# Altium schematic sheet VCC38.SchDoc
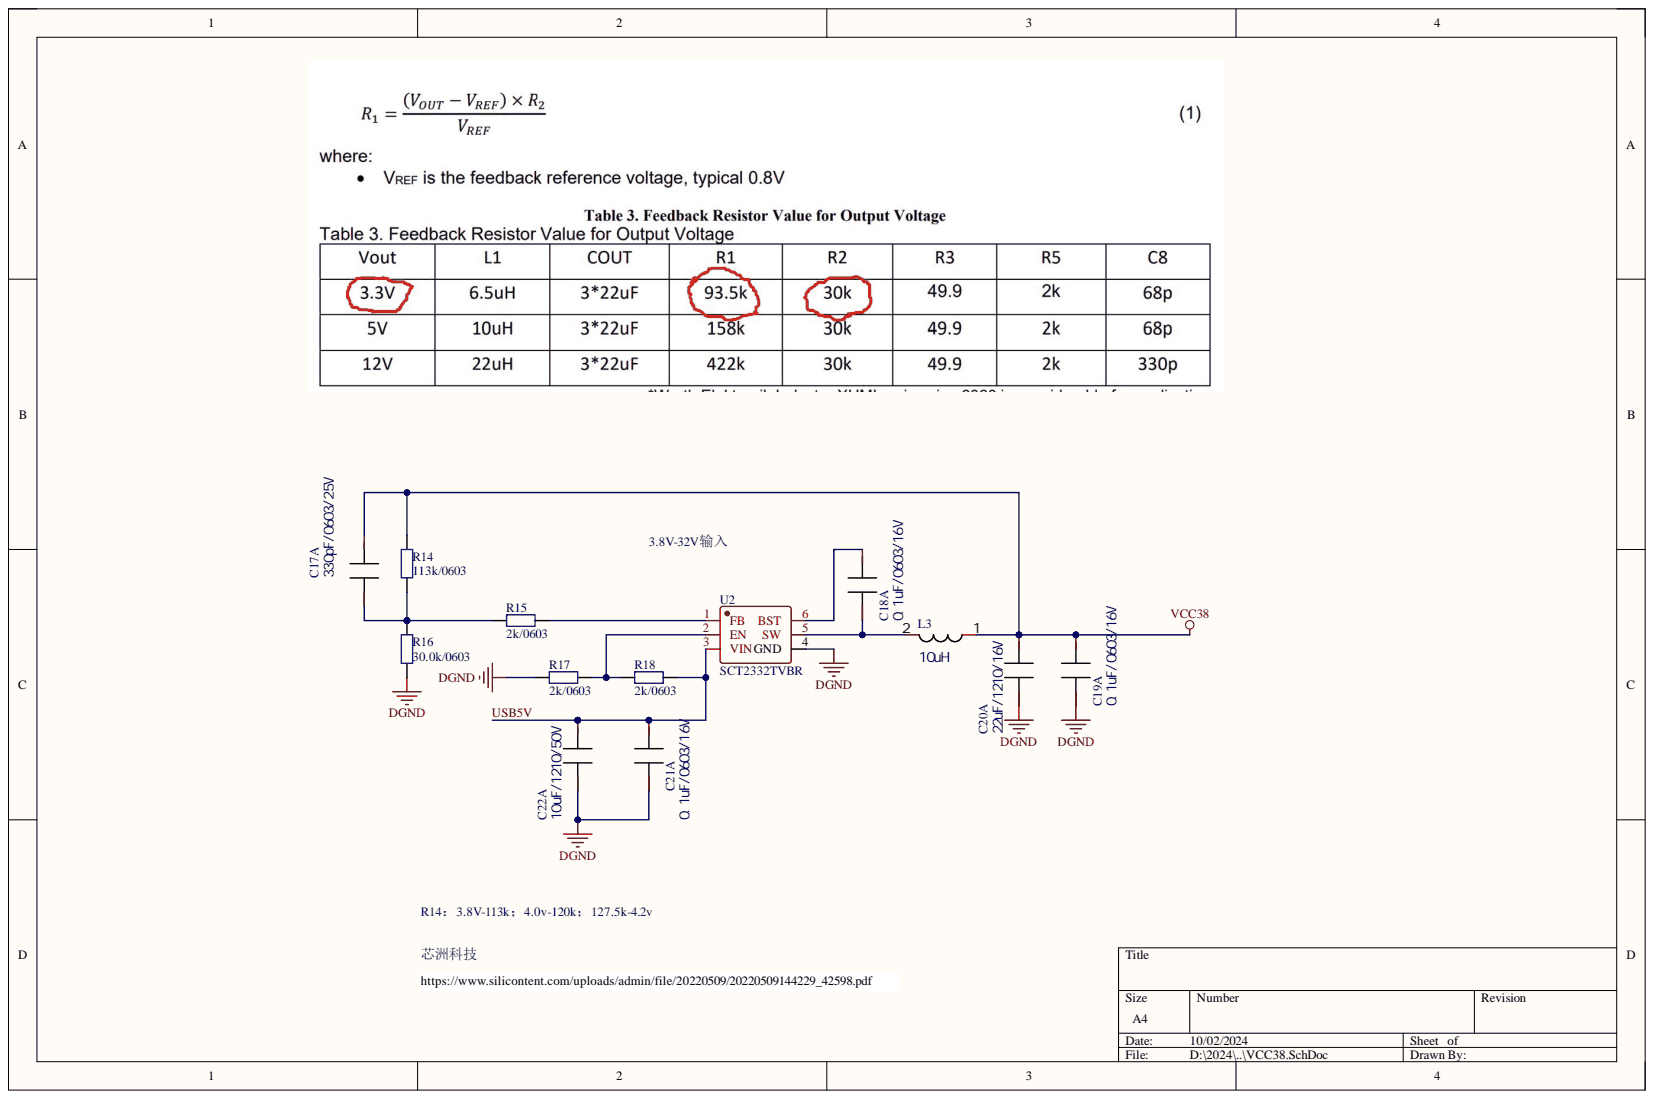
[diagram p0: VCC38.SchDoc]

## Parts
C17  330pF/0603/25V  [CAP0603]  (part A)
C18  0.1uF/0603/16V  [CAP0603]  (part A)
C19  0.1uF/0603/16V  [CAP0603]  (part A)
C20  22uF/1210/16V  [CAP1210-CAPC3225X140N]  (part A)
C21  0.1uF/0603/16V  [CAP0603]  (part A)
C22  10uF/1210/50V  [CAP1210-CAPC3225X140N]  (part A)
L3  10uH  [IND-SMD_L4.7-W4.7-6.5uH]
R14  113k/0603  [RES0603]
R15  2k/0603  [RES0603]
R16  30.0k/0603  [RES0603]
R17  2k/0603  [RES0603]
R18  2k/0603  [RES0603]
U2  SCT2332TVBR  [SCT2332TVBR-TSOT23-6]

## Nets
DGND: C19.2 C20.2 C21.2 C22.2 R16.2 R17.2 U2.4(GND)
VCC38: C17.1 C19.1 C20.1 L3.1 R14.1
USB5V: C21.1 C22.1 R18.1 U2.3(VIN)
NetC17_2: C17.2 R14.2 R15.2 R16.1
NetC18_1: C18.1 U2.6(BST)
NetC18_2: C18.2 L3.2 U2.5(SW)
NetR15_1: R15.1 U2.1(FB)
NetR17_1: R17.1 R18.2 U2.2(EN)

## Library data of the parts
C17 = CAP0603: SURFACE MOUNT CAPACITOR 0.031 X 0.061 INCHES; Manufacturer #1: IPC SM-782 STD.; Add into BOM: yes; Origin Footprint: 0603; Sim_Analog_Order: Model$; Component Kind: Standard; Convert to PCB: yes; Device: CAP0603; Name: CAP0603; OldName: CAP0603; PCB DECAL: 0603; Symbol: CAP0603; Value: 330pF/0603/25V
C18 C19 C21 = CAP0603: SURFACE MOUNT CAPACITOR 0.031 X 0.061 INCHES; Manufacturer #1: IPC SM-782 STD.; Add into BOM: yes; Origin Footprint: 0603; Sim_Analog_Order: Model$; Value: 0.1uF/0603/50V; Component Kind: Standard; Convert to PCB: yes; Device: CAP0603; Name: CAP0603; OldName: CAP0603; PCB DECAL: 0603; Symbol: CAP0603
C20 = CAP0603: SURFACE MOUNT CAPACITOR 0.031 X 0.061 INCHES; Manufacturer #1: IPC SM-782 STD.; Add into BOM: yes; Origin Footprint: 0603; Sim_Analog_Order: Model$; Value: 22uF/1210/35V; Component Kind: Standard; Convert to PCB: yes; Device: CAP0603; Name: CAP0603; OldName: CAP0603; PCB DECAL: 0603; Symbol: CAP0603
C22 = CAP0603: SURFACE MOUNT CAPACITOR 0.031 X 0.061 INCHES; Manufacturer #1: IPC SM-782 STD.; Add into BOM: yes; Origin Footprint: 0603; Sim_Analog_Order: Model$; Value: 10uF/1210/35V; Component Kind: Standard; Convert to PCB: yes; Device: CAP0603; Name: CAP0603; OldName: CAP0603; PCB DECAL: 0603; Symbol: CAP0603
L3 = IND-MOLDED: Add into BOM: yes; Description" MOLDED INDUCTOR, 0_5: PIN SPACING; Origin Footprint: L_0650; Sim_Analog_Order: Model$; Sim_Analog_Prefix: L; Component Kind: Standard; Convert to PCB: yes; Device: IND-MOLDED; Name: IND-MOLDED; OldName: IND-MOLDED; PCB DECAL: L_0650; Symbol: IND-MOLDED; Value: 10uH
R14-R18 = Res2: Resistor; Component Kind: Standard; LatestRevisionDate: 17-Jul-2002; LatestRevisionNote: Re-released for DXP Platform.; Library Name: Miscellaneous Devices.IntLib; PackageDescription: Axial Device, Thru-Hole; 2 Leads; 0.4 in Pin Spacing; PackageReference: AXIAL-0.4; Published: 8-Jun-2000; Signal Integrity: Res; Simulation: RESISTOR; Publisher: Altium Limited
U2 = SCT2332TVBR: 3.8V-32V Vin, 3A Synchronous Step-down DCDC Converter; Component Kind: Standard; Library Name: ChargingPile.SchLib
